# Altium schematic sheet P10_uSD.SchDoc(P10_uSD)
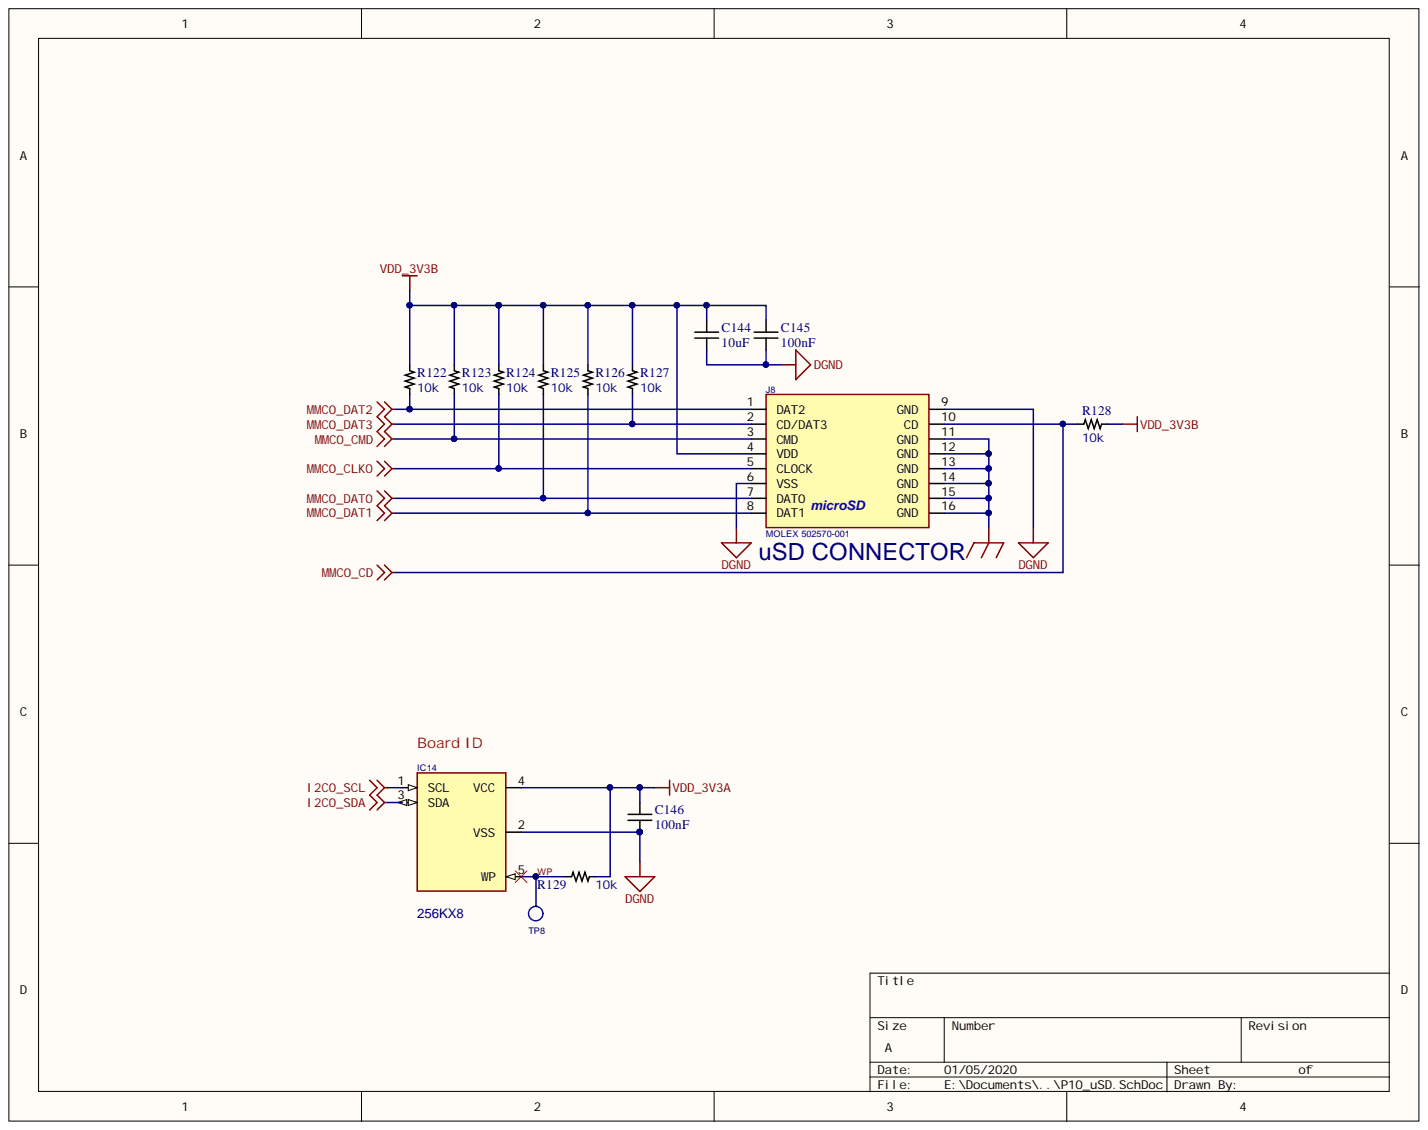
[diagram p0: P10_uSD.SchDoc]

## Parts
C144  Capacitance: 10uF  [CAP0805]  (hidden comment: C2012X6S1A106K085AC)
C145  Capacitance: 100nF  [CAP0402]  (hidden comment: C0402C104K4RACTU)
C146  Capacitance: 100nF  [CAP0402]  (hidden comment: C0402C104K4RACTU)
IC14  24LC32A  [SOT-23-5]  (value not drawn)
J8  MOLEX 502570-001  [SD-MICRO-SCHA5B0300]
R122  Resistance: 10k  [RES0402]  (hidden comment: RC0402FR-0710KL)
R123  Resistance: 10k  [RES0402]  (hidden comment: RC0402FR-0710KL)
R124  Resistance: 10k  [RES0402]  (hidden comment: RC0402FR-0710KL)
R125  Resistance: 10k  [RES0402]  (hidden comment: RC0402FR-0710KL)
R126  Resistance: 10k  [RES0402]  (hidden comment: RC0402FR-0710KL)
R127  Resistance: 10k  [RES0402]  (hidden comment: RC0402FR-0710KL)
R128  Resistance: 10k  [RES0402]  (hidden comment: RC0402FR-0710KL)
R129  Resistance: 10k  [RES0402]  (hidden comment: RC0402FR-0710KL)
TP8  TESTPT1  [tp1-8040]  (value not drawn)  (no BOM)

## Nets
DGND: C144.2 C145.2 C146.2 IC14.2(VSS) J8.6(VSS) J8.9(GND)
GND_EARTH: J8.11(GND) J8.12(GND) J8.13(GND) J8.14(GND) J8.15(GND) J8.16(GND)
I2C0_SCL: IC14.1(SCL)
I2C0_SDA: IC14.3(SDA)
MMC0_CD: J8.10(CD) R128.2
MMC0_CLKO: J8.5(CLOCK) R124.1
MMC0_CMD: J8.3(CMD) R123.1
MMC0_DAT0: J8.7(DAT0) R125.1
MMC0_DAT1: J8.8(DAT1_microSD) R126.1
MMC0_DAT2: J8.1(DAT2) R122.1
MMC0_DAT3: J8.2(CD/DAT3) R127.1
VDD_3V3A: C146.1 IC14.4(VCC) R129.2
VDD_3V3B: C144.1 C145.1 J8.4(VDD) R122.2 R123.2 R124.2 R125.2 R126.2 R127.2 R128.1
WP: IC14.5(WP) R129.1 TP8.1

## Library data of the parts
C144 = CAP_10uF: MLCC 10uF 0805 SMD; Manufacturer: TDK; Voltage Rating (DC): 10V; Tolerance: 10%; Dielectric Material: Ceramic; Dielectric: X6S; Width: 0.049inch; Weight: 0.000194oz; Voltage Rating: 10V; Thickness: 0.037inch; Termination: SMD/SMT; Supplier Part Number 1: 445-7613-1-ND; Supplier 1: Digi-Key; Stock 1: 46852; RoHS: Yes; REACH SVHC: No SVHC; Pricing 1: 1=0.30000, 10=0.20500, 100=0.11610, 500=0.08198, 1000=0.07173 (USD); Packaging: Tape and Reel; Package Quantity: 4000; Mount: Surface Mount; Min Operating Temperature: -55°C; Max Operating Temperature: 105°C; Manufacturer Part Number: C2012X6S1A106K085AC; Length: 2mm; Lead Free: Lead Free; Height: 1.25mm; Depth: 1.25mm; Composition: Ceramic; ComponentLink3URL: https://datasheet.ciiva.com/6290/tdkmlcccaprange-6290501.pdf?src-supplier=Digi-Key; ComponentLink3Description: Datasheet; Component Kind: Standard; Case Code (Metric): 2012; Case Code (Imperial): 0805; Case/Package: 0805; Library Name: Orient.SchLib
C145 C146 = CAP_100nF: MLCC 100nF 0402 SMD; Manufacturer: KEMET; Voltage Rating (DC): 16V; Tolerance: 10%; Dissipation Factor: 3.5%; Dielectric: X7R; Width: 0.5mm; Voltage Rating: 16V; Termination: SMD/SMT; Supplier Part Number 1: 399-3521-1-ND; Supplier 1: Digi-Key; Stock 1: 6512243; RoHS: Yes; Radiation Hardening: No; Pricing 1: 1=0.10000, 10=0.04200, 100=0.01860, 500=0.01328, 1000=0.01044, 2500=0.00949, 5000=0.00873 (USD); Packaging: Tape and Reel; Package Quantity: 10000; Mount: Surface Mount; Min Operating Temperature: -55°C; Max Operating Temperature: 125°C; Manufacturer Part Number: C0402C104K4RACTU; Length: 1mm; Height: 0.5mm; Depth: 0.5mm; Contact Plating: Tin,Matte; ComponentLink2URL: https://datasheet.ciiva.com/18192/getdatasheetpartid-385384-18192412.pdf?src-supplier=Verical; ComponentLink2Description: Datasheet; Component Kind: Standard; Case Code (Metric): 1005; Case Code (Imperial): 0402; Case/Package: 0402; Library Name: Orient.SchLib
IC14 = 24LC32A: IC EEPROM 32KBIT 400KHZ SOT23-5; Manufacturer Part Number: 24LC32AT-I/OT; Manufacturer: Microchip Technology; Voltage - Supply: 2.5 V ~ 5.5 V; Memory Size: 32K (4K x 8); Interface: I²C, 2-Wire Serial; Format - Memory: EEPROMs - Serial; Supplier Part Number 1: 24LC32AT-I/OTDKR-ND; Supplier Device Package: SOT-23-5; Supplier 1: Digi-Key; Stock 1: 8694; Speed: 400kHz; RoHS: RoHS Compliant; Pricing 1: 1=0.5, 10=0.32, 25=0.31, 100=0.3 (USD); Packaging: Digi-Reel®; Package / Case: SC-74A, SOT-753; Operating Temperature: -40°C ~ 85°C; Memory Type: EEPROM; ComponentLink1URL: http://ww1.microchip.com/downloads/en/DeviceDoc/21713M.pdf; ComponentLink1Description: http://ww1.microchip.com/downloads/en/DeviceDoc/21713M.pdf; Component Kind: Standard; Category: Integrated Circuits (ICs); Library Name: Orient.SchLib
J8 = microSD_CONN_2: CONN MICRO SD R/A PUSH-PUSH SMD; Manufacturer Part Number: 5025700893; Manufacturer: Molex Inc; Number of Positions: 10 (8 + 2); Insertion, Removal Method: Push In, Push Out; Connector Type: Connector and Ejector; Card Type: Secure Digital - microSD™ TransFlash; Supplier Part Number 1: WM19093TR-ND; Supplier 1: Digi-Key; Stock 1: 16900; RoHS: RoHS Compliant; Pricing 1: 1300=2.16645, 2600=2.01703, 6500=1.94233 (USD); Packaging: Tape & Reel (TR); Mounting Type: Surface Mount, Right Angle; Mounting Feature: Normal, Standard - Top; Height Above Board: 0.071" (1.80mm); Features: Switch; Ejector Side: -; Contact Finish Thickness: 20µin (0.51µm); Contact Finish: Gold; ComponentLink1URL: http://www.molex.com/pdm_docs/sd/5025700893_sd.pdf; ComponentLink1Description: http://www.molex.com/pdm_docs/sd/5025700893_sd.pdf; Component Kind: Standard; Category: Connectors, Interconnects; Library Name: Orient.SchLib
R122-R129 = RES_10k: Resistor 10kOhm 0402 SMD; Manufacturer: Yageo; Working Voltage: 50V; Tolerance: 1%; Temperature Coefficient: 100ppm/°C; Power Rating: 62.5mW; Width: 0.5mm; Voltage Rating (DC): 50V; Voltage Rating: 50V; Termination: SMD/SMT; Supplier 1: Digi-Key; Stock 1: 72891652; RoHS: Yes; REACH SVHC: No SVHC; Pricing 1: 1=0.10000, 10=0.01500, 100=0.00600, 1000=0.00270, 2500=0.00235, 5000=0.00194 (USD); Packaging: Tape and Reel; Number of Terminations: 2; Number of Pins: 2; Min Operating Temperature: -55°C; Military Standard: Not; Max Operating Temperature: 155°C; Manufacturer Part Number: RC0402FR-0710KL; Length: 1mm; Lead Pitch: 0.2mm; Lead Free: Lead Free; Height: 0.32mm; Depth: 0.5mm; Composition: Thick Film; ComponentLink7URL: https://datasheet.ciiva.com/12667/pyu-rc0402-51-rohs-l-6-r-12667067.pdf?src-supplier=Mouser; ComponentLink7Description: https://datasheet.ciiva.com/12667/pyu-rc0402-51-rohs-l-6-r-12667067.pdf?src-supplier=Mouser; ComponentLink6URL: https://datasheet.ciiva.com/22747/1018594-22747512.pdf?src-supplier=Element14; ComponentLink6Description: https://datasheet.ciiva.com/22747/1018594-22747512.pdf?src-supplier=Element14; ComponentLink5URL: https://datasheet.ciiva.com/7849/1784430-7849815.pdf?src-supplier=Element14; ComponentLink5Description: https://datasheet.ciiva.com/7849/1784430-7849815.pdf?src-supplier=Element14; ComponentLink4URL: https://datasheet.ciiva.com/7849/1686128-7849814.pdf?src-supplier=Element14; ComponentLink4Description: https://datasheet.ciiva.com/7849/1686128-7849814.pdf?src-supplier=Element14; ComponentLink3URL: https://datasheet.ciiva.com/7849/1784430-7849813.pdf?src-supplier=Element14; ComponentLink3Description: https://datasheet.ciiva.com/7849/1784430-7849813.pdf?src-supplier=Element14; ComponentLink2URL: https://datasheet.ciiva.com/7983/1018594-7983486.pdf?src-supplier=Element14; ComponentLink2Description: https://datasheet.ciiva.com/7983/1018594-7983486.pdf?src-supplier=Element14; ComponentLink1URL: https://datasheet.ciiva.com/7660/38568-7660787.pdf?src-supplier=Element14; ComponentLink1Description: https://datasheet.ciiva.com/7660/38568-7660787.pdf?src-supplier=Element14; Component Kind: Standard; Case Code (Metric): 1005; Case Code (Imperial): 0402; Case/Package: 0402; Library Name: Orient.SchLib
TP8 = TESTPT1: Library Name: Orient.SchLib
